# Altium schematic sheet PAGE3-PROCESSING.SchDoc
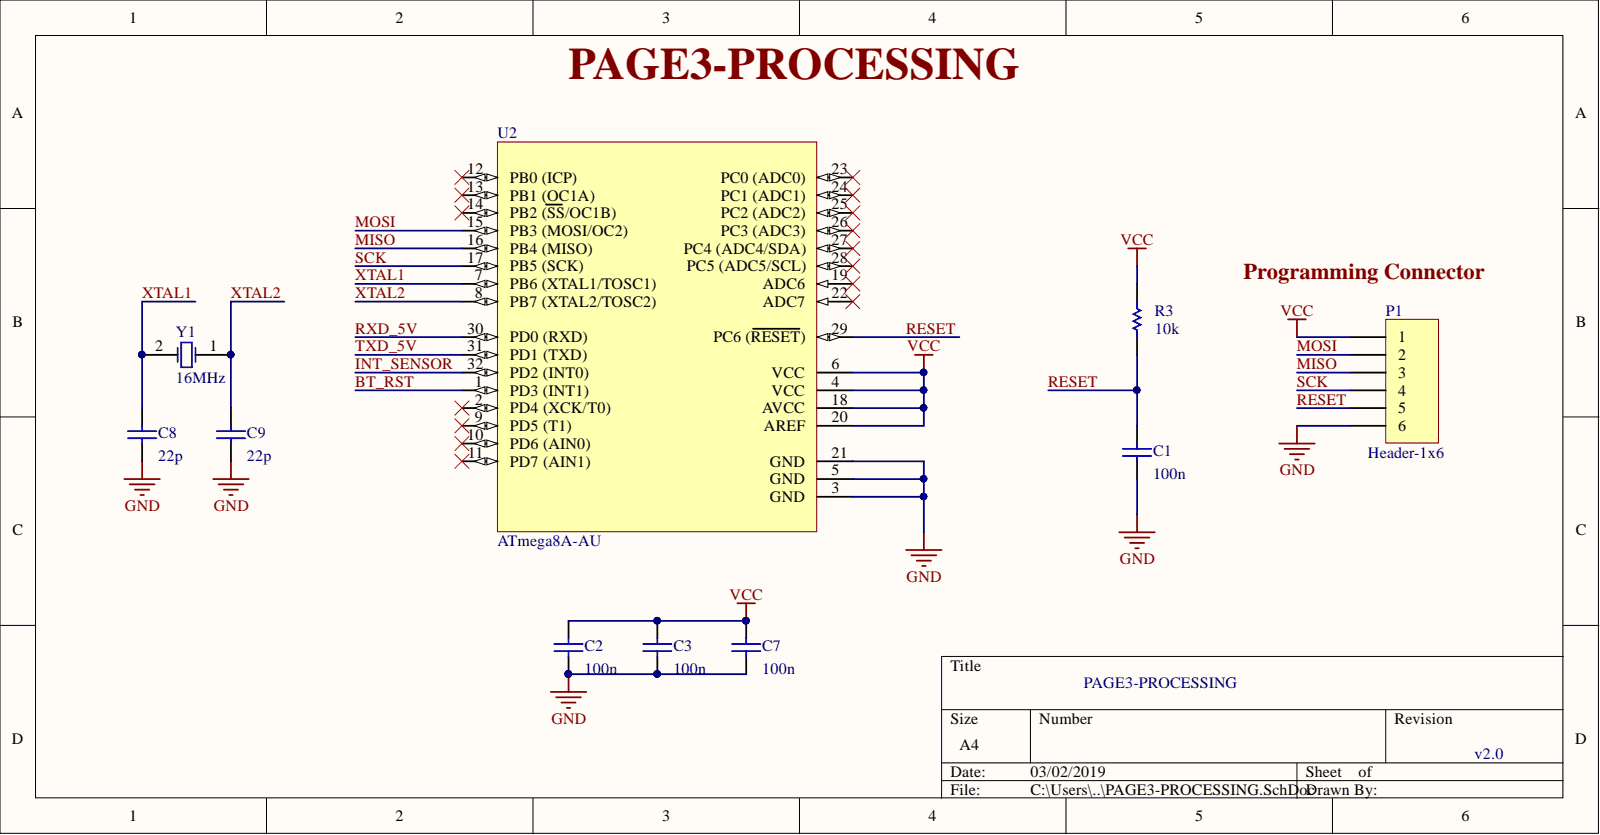
[diagram p0: PAGE3-PROCESSING.SchDoc]

## Parts
C1  100n  [OF-SMD2012R]
C2  100n  [OF-SMD2012R]
C3  100n  [OF-SMD2012R]
C7  100n  [OF-SMD2012R]
C8  22p  [OF-SMD2012R]
C9  22p  [OF-SMD2012R]
P1  Header-1x6  [header_1X6]
R3  10k  [Res SMD 0805]
U2  ATmega8A-AU  [atemag8A-AU]
Y1  16MHz  [SMD HC49SM]

## Nets
GND: C1.1 C2.1 C3.1 C7.1 C8.1 C9.1 P1.6 U2.3(GND) U2.5(GND) U2.21(GND)
VCC: C2.2 C3.2 C7.2 P1.1 R3.2 U2.4(VCC) U2.6(VCC) U2.18(AVCC) U2.20(AREF)
BT_RST: U2.1(PD3_(INT1))
INT_SENSOR: U2.32(PD2_(INT0))
MISO: P1.3 U2.16(PB4_(MISO))
MOSI: P1.2 U2.15(PB3_(MOSI/OC2))
RESET: C1.2 P1.5 R3.1 U2.29(PC6_(RESET))
RXD_5V: U2.30(PD0_(RXD))
SCK: P1.4 U2.17(PB5_(SCK))
TXD_5V: U2.31(PD1_(TXD))
XTAL1: C8.2 U2.7(PB6_(XTAL1/TOSC1)) Y1.2
XTAL2: C9.2 U2.8(PB7_(XTAL2/TOSC2)) Y1.1

Not connected: U2 2(PD4_(XCK/T0)) 9(PD5_(T1)) 10(PD6_(AIN0)) 11(PD7_(AIN1)) 12(PB0_(ICP)) 13(PB1_(OC1A)) 14(PB2_(SS/OC1B)) 19(ADC6) 22(ADC7) 23(PC0_(ADC0)) 24(PC1_(ADC1)) 25(PC2_(ADC2)) 26(PC3_(ADC3)) 27(PC4_(ADC4/SDA)) 28(PC5_(ADC5/SCL))

## Library data of the parts
C1-C3 C7-C9 = Capacitor: Manufacture: YAGEO; Manufacture code: CC0805KRX7R9BB104; Implementation: Yes; Oredering code: CC0805KRX7R9104; Component Kind: Standard; Datasheet: http://www.tme.eu/hr/Document/b4dafe2be75e8e02f2f5bf31efbc9903/cc_x7r_yageo.pdf; Description: Capacitor; Distributor: TME.eu; Library Name: Capacitors_20150530.IntLib
  C1-C3 C7: Value: 100n
  C8 C9: Value: 22p
P1 = header_1x6: Header, 6-Pin; Implementation: Yes; Value: Header 1x6; Component Kind: Standard; Distributor: Sprint Electronic; Library Name: Header_20150530.IntLib
R3 = Res 1k: Resistor: thick film; SMD; 0805; 1kO; 0.125W; ±5%; -55÷125°C; Implementation: Yes; Manifacturer: ROYAL OHM; Manifacturer code: 0805S8J0102T5E; Ordering code: SMD0805-1K; Component Kind: Standard; Datasheet: http://www.tme.eu/en/Document/cd08025e8728292bb79d3f4577300b82/rezystor-smd.pdf; Distributor: TME.eu; Library Name: ResistorsLib_20150530.IntLib; Value: 10k
U2 = ATmega8A-AU: 8-bit Atmel Microcontroller with 8KB In-System Programmable Flash; Implementation: Yes; Oredering code: 1748532; Component Kind: Standard; Datasheet: http://www.atmel.com/Images/Atmel-8159-8-bit-AVR-microcontroller-ATmega8A_datasheet.pdf; Distributor: Farnell; Library Name: AVR_atmega_20150530.IntLib; Manufacturer: Atmel; Manufacturer code: ATMEGA8A-AU; Value: ATMEGA8A-AU
Y1 = Crystal Quartz 16MHz: Resonator: quartz; 16MHz; ±30ppm; 20pF; SMD; HC49SM; Implementation: Yes; Manifacturer: YIC; Ordering code: 16.00M-SMDHC49S; Component Kind: Standard; Datasheet: http://www.tme.eu/en/Document/6527d4ac6cd20f858e4312ccc80ef7ce/YIC-49US_49SMT-quartz_crystal.pdf; Distributor: TME.eu; Library Name: CrystalQuartz_20150530.IntLib; Value: 16MHz
